# Altium schematic sheet FPGA.SchDoc
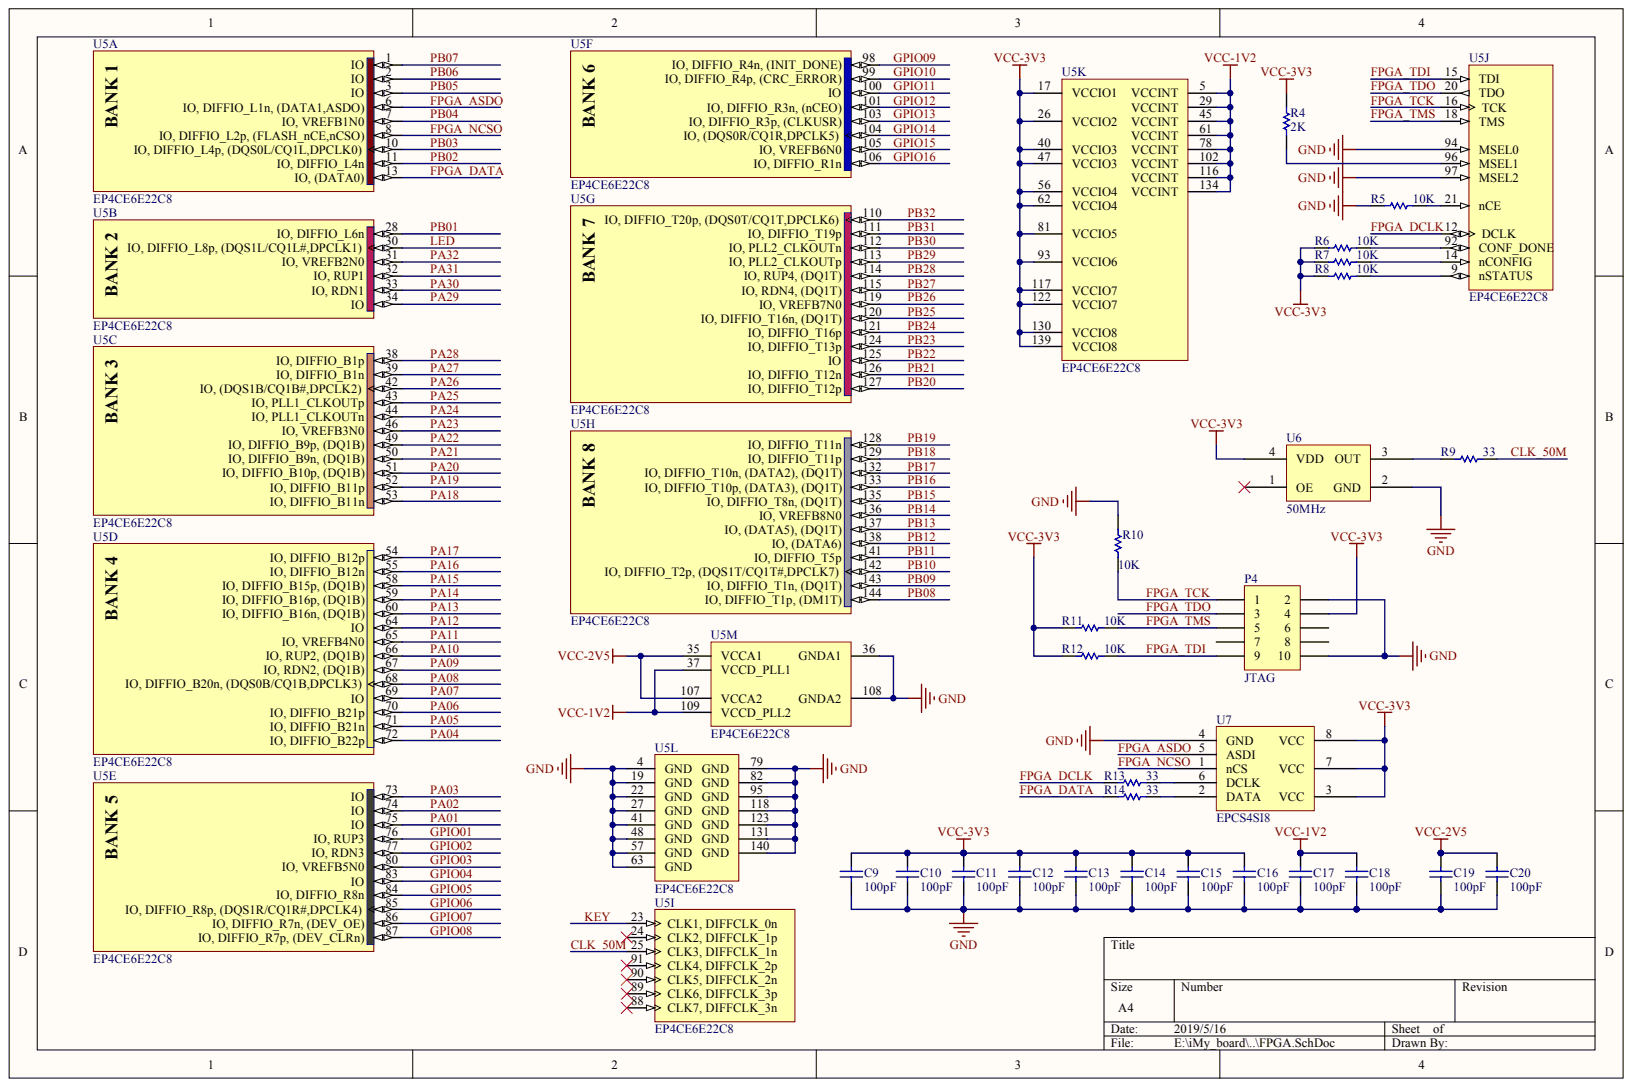
[diagram p0: FPGA.SchDoc]

## Parts
C9  value 100pF  (hidden comment: Cap)
C10  value 100pF  (hidden comment: Cap)
C11  value 100pF  (hidden comment: Cap)
C12  value 100pF  (hidden comment: Cap)
C13  value 100pF  (hidden comment: Cap)
C14  value 100pF  (hidden comment: Cap)
C15  value 100pF  (hidden comment: Cap)
C16  value 100pF  (hidden comment: Cap)
C17  value 100pF  (hidden comment: Cap)
C18  value 100pF  (hidden comment: Cap)
C19  value 100pF  (hidden comment: Cap)
C20  value 100pF  (hidden comment: Cap)
P4  JTAG
R4  value 2K  (hidden comment: Res1)
R5  value 10K  (hidden comment: Res1)
R6  value 10K  (hidden comment: Res1)
R7  value 10K  (hidden comment: Res1)
R8  value 10K  (hidden comment: Res1)
R9  value 33  (hidden comment: Res1)
R10  value 10K  (hidden comment: Res1)
R11  value 10K  (hidden comment: Res1)
R12  value 10K  (hidden comment: Res1)
R13  value 33  (hidden comment: Res1)
R14  value 33  (hidden comment: Res1)
U5  EP4CE6E22C8  (parts A,B,C,D,E,F,G,H,I,J,K,L,M)
U6  50MHz
U7  EPCS4SI8

## Nets
GND: C9.1 C10.1 C11.1 C12.1 C13.1 C14.1 C15.1 C16.1 C17.1 C18.1 C19.1 C20.1 P4.2 P4.10 R5.2 R10.2 U5.4(GND) U5.19(GND) U5.22(GND) U5.27(GND) U5.36(GNDA1) U5.41(GND) U5.48(GND) U5.57(GND) U5.63(GND) U5.79(GND) U5.82(GND) U5.94(MSEL0) U5.95(GND) U5.97(MSEL2) U5.108(GNDA2) U5.118(GND) U5.123(GND) U5.131(GND) U5.140(GND) U6.2(GND) U7.4(GND)
VCC-1V2: C17.2 C18.2 U5.5(VCCINT) U5.29(VCCINT) U5.37(VCCD_PLL1) U5.45(VCCINT) U5.61(VCCINT) U5.78(VCCINT) U5.102(VCCINT) U5.109(VCCD_PLL2) U5.116(VCCINT) U5.134(VCCINT)
VCC-2V5: C19.2 C20.2 U5.35(VCCA1) U5.107(VCCA2)
VCC-3V3: C9.2 C10.2 C11.2 C12.2 C13.2 C14.2 C15.2 C16.2 P4.4 R4.1 R6.2 R7.2 R8.2 R11.2 R12.2 U5.17(VCCIO1) U5.26(VCCIO2) U5.40(VCCIO3) U5.47(VCCIO3) U5.56(VCCIO4) U5.62(VCCIO4) U5.81(VCCIO5) U5.93(VCCIO6) U5.117(VCCIO7) U5.122(VCCIO7) U5.130(VCCIO8) U5.139(VCCIO8) U6.4(VDD) U7.3(VCC) U7.7(VCC) U7.8(VCC)
CLK_50M: R9.1 U5.25(CLK3,_DIFFCLK_1n)
FPGA_ASDO: U5.6(IO,_DIFFIO_L1n,_(DATA1,ASDO)) U7.5(ASDI)
FPGA_DATA: R14.2 U5.13(IO,_(DATA0))
FPGA_DCLK: R13.2 U5.12(DCLK)
FPGA_NCSO: U5.8(IO,_DIFFIO_L2p,_(FLASH_nCE,nCSO)) U7.1(nCS)
FPGA_TCK: P4.1 R10.1 U5.16(TCK)
FPGA_TDI: P4.9 R12.1 U5.15(TDI)
FPGA_TDO: P4.3 U5.20(TDO)
FPGA_TMS: P4.5 R11.1 U5.18(TMS)
GPIO01: U5.76(IO,_RUP3)
GPIO02: U5.77(IO,_RDN3)
GPIO03: U5.80(IO,_VREFB5N0)
GPIO04: U5.83(IO)
GPIO05: U5.84(IO,_DIFFIO_R8n)
GPIO06: U5.85(IO,_DIFFIO_R8p,_(DQS1R/CQ1R#,DPCLK4))
GPIO07: U5.86(IO,_DIFFIO_R7n,_(DEV_OE))
GPIO08: U5.87(IO,_DIFFIO_R7p,_(DEV_CLRn))
GPIO09: U5.98(IO,_DIFFIO_R4n,_(INIT_DONE))
GPIO10: U5.99(IO,_DIFFIO_R4p,_(CRC_ERROR))
GPIO11: U5.100(IO)
GPIO12: U5.101(IO,_DIFFIO_R3n,_(nCEO))
GPIO13: U5.103(IO,_DIFFIO_R3p,_(CLKUSR))
GPIO14: U5.104(IO,_(DQS0R/CQ1R,DPCLK5))
GPIO15: U5.105(IO,_VREFB6N0)
GPIO16: U5.106(IO,_DIFFIO_R1n)
KEY: U5.23(CLK1,_DIFFCLK_0n)
LED: U5.30(IO,_DIFFIO_L8p,_(DQS1L/CQ1L#,DPCLK1))
PA01: U5.75(IO)
PA02: U5.74(IO)
PA03: U5.73(IO)
PA04: U5.72(IO,_DIFFIO_B22p)
PA05: U5.71(IO,_DIFFIO_B21n)
PA06: U5.70(IO,_DIFFIO_B21p)
PA07: U5.69(IO)
PA08: U5.68(IO,_DIFFIO_B20n,_(DQS0B/CQ1B,DPCLK3))
PA09: U5.67(IO,_RDN2,_(DQ1B))
PA10: U5.66(IO,_RUP2,_(DQ1B))
PA11: U5.65(IO,_VREFB4N0)
PA12: U5.64(IO)
PA13: U5.60(IO,_DIFFIO_B16n,_(DQ1B))
PA14: U5.59(IO,_DIFFIO_B16p,_(DQ1B))
PA15: U5.58(IO,_DIFFIO_B15p,_(DQ1B))
PA16: U5.55(IO,_DIFFIO_B12n)
PA17: U5.54(IO,_DIFFIO_B12p)
PA18: U5.53(IO,_DIFFIO_B11n)
PA19: U5.52(IO,_DIFFIO_B11p)
PA20: U5.51(IO,_DIFFIO_B10p,_(DQ1B))
PA21: U5.50(IO,_DIFFIO_B9n,_(DQ1B))
PA22: U5.49(IO,_DIFFIO_B9p,_(DQ1B))
PA23: U5.46(IO,_VREFB3N0)
PA24: U5.44(IO,_PLL1_CLKOUTn)
PA25: U5.43(IO,_PLL1_CLKOUTp)
PA26: U5.42(IO,_(DQS1B/CQ1B#,DPCLK2))
PA27: U5.39(IO,_DIFFIO_B1n)
PA28: U5.38(IO,_DIFFIO_B1p)
PA29: U5.34(IO)
PA30: U5.33(IO,_RDN1)
PA31: U5.32(IO,_RUP1)
PA32: U5.31(IO,_VREFB2N0)
PB01: U5.28(IO,_DIFFIO_L6n)
PB02: U5.11(IO,_DIFFIO_L4n)
PB03: U5.10(IO,_DIFFIO_L4p,_(DQS0L/CQ1L,DPCLK0))
PB04: U5.7(IO,_VREFB1N0)
PB05: U5.3(IO)
PB06: U5.2(IO)
PB07: U5.1(IO)
PB08: U5.144(IO,_DIFFIO_T1p,_(DM1T))
PB09: U5.143(IO,_DIFFIO_T1n,_(DQ1T))
PB10: U5.142(IO,_DIFFIO_T2p,_(DQS1T/CQ1T#,DPCLK7))
PB11: U5.141(IO,_DIFFIO_T5p)
PB12: U5.138(IO,_(DATA6))
PB13: U5.137(IO,_(DATA5),_(DQ1T))
PB14: U5.136(IO,_VREFB8N0)
PB15: U5.135(IO,_DIFFIO_T8n,_(DQ1T))
PB16: U5.133(IO,_DIFFIO_T10p,_(DATA3),_(DQ1T))
PB17: U5.132(IO,_DIFFIO_T10n,_(DATA2),_(DQ1T))
PB18: U5.129(IO,_DIFFIO_T11p)
PB19: U5.128(IO,_DIFFIO_T11n)
PB20: U5.127(IO,_DIFFIO_T12p)
PB21: U5.126(IO,_DIFFIO_T12n)
PB22: U5.125(IO)
PB23: U5.124(IO,_DIFFIO_T13p)
PB24: U5.121(IO,_DIFFIO_T16p)
PB25: U5.120(IO,_DIFFIO_T16n,_(DQ1T))
PB26: U5.119(IO,_VREFB7N0)
PB27: U5.115(IO,_RDN4,_(DQ1T))
PB28: U5.114(IO,_RUP4,_(DQ1T))
PB29: U5.113(IO,_PLL2_CLKOUTp)
PB30: U5.112(IO,_PLL2_CLKOUTn)
PB31: U5.111(IO,_DIFFIO_T19p)
PB32: U5.110(IO,_DIFFIO_T20p,_(DQS0T/CQ1T,DPCLK6))
NetR4_2: R4.2 U5.96(MSEL1)
NetR5_1: R5.1 U5.21(nCE)
NetR6_1: R6.1 U5.92(CONF_DONE)
NetR7_1: R7.1 U5.14(nCONFIG)
NetR8_1: R8.1 U5.9(nSTATUS)
NetR9_2: R9.2 U6.3(OUT)
NetR13_1: R13.1 U7.6(DCLK)
NetR14_1: R14.1 U7.2(DATA)

Not connected: P4 6 7 8; U5 24(CLK2,_DIFFCLK_1p) 88(CLK7,_DIFFCLK_3n) 89(CLK6,_DIFFCLK_3p) 90(CLK5,_DIFFCLK_2n) 91(CLK4,_DIFFCLK_2p); U6 1(OE)

## Library data of the parts
C9-C20 P4 R4-R14 U5-U7 = (no symbol name)
  C9-C20: Published: 8-Jun-2000; LatestRevisionDate: 17-Jul-2002; LatestRevisionNote: Re-released for DXP Platform.; PackageReference: RAD-0.3; PackageDescription: Radial Cap, Thru-Hole; 2 Leads; 0.3 in Pin Spacing
  P4: LatestRevisionDate: 17-Jul-2002; LatestRevisionNote: Re-released for DXP Platform.
  R4-R14: Published: 8-Jun-2000; LatestRevisionDate: 17-Jul-2002; LatestRevisionNote: Re-released for DXP Platform.; PackageReference: AXIAL-0.3; PackageDescription: Axial Device, Thru-Hole; 2 Leads; 0.3 in Pin Spacing
  U5: Published: 3-May-2010; DatasheetVersion: ver1.2, Mar-2010; PackageVersion: ver16.0, Dec-2009; PackageReference: EQFP144; PackageDescription: 144-Pin Plastic Enhanced Quad Flat Pack (EQFP144) - Wire Bond; Supplier 1: Digi-Key; Supplier Part Number 1: EP4CE6E22C8-ND; Code_JEDEC: MS-026-BFB; ComponentLink1Description: Manufacturer Link; ComponentLink1URL: http://www.altera.com/; ComponentLink2Description: Handbook; ComponentLink2URL: http://www.altera.com/literature/hb/cyclone-iv/cyclone4-handbook.pdf; ComponentLink3Description: Pinout Specification; ComponentLink3URL: http://www.altera.com/literature/lit-dp.jsp?category=cyc%204&showspreadsheet=y; ComponentLink4Description: Packaging Specification; ComponentLink4URL: http://www.altera.com/literature/ds/dspkg.pdf
  C9-C20 P4 R4-R14 U5: Publisher: Altium Limited
